# Altium schematic sheet ad7124.SchDoc
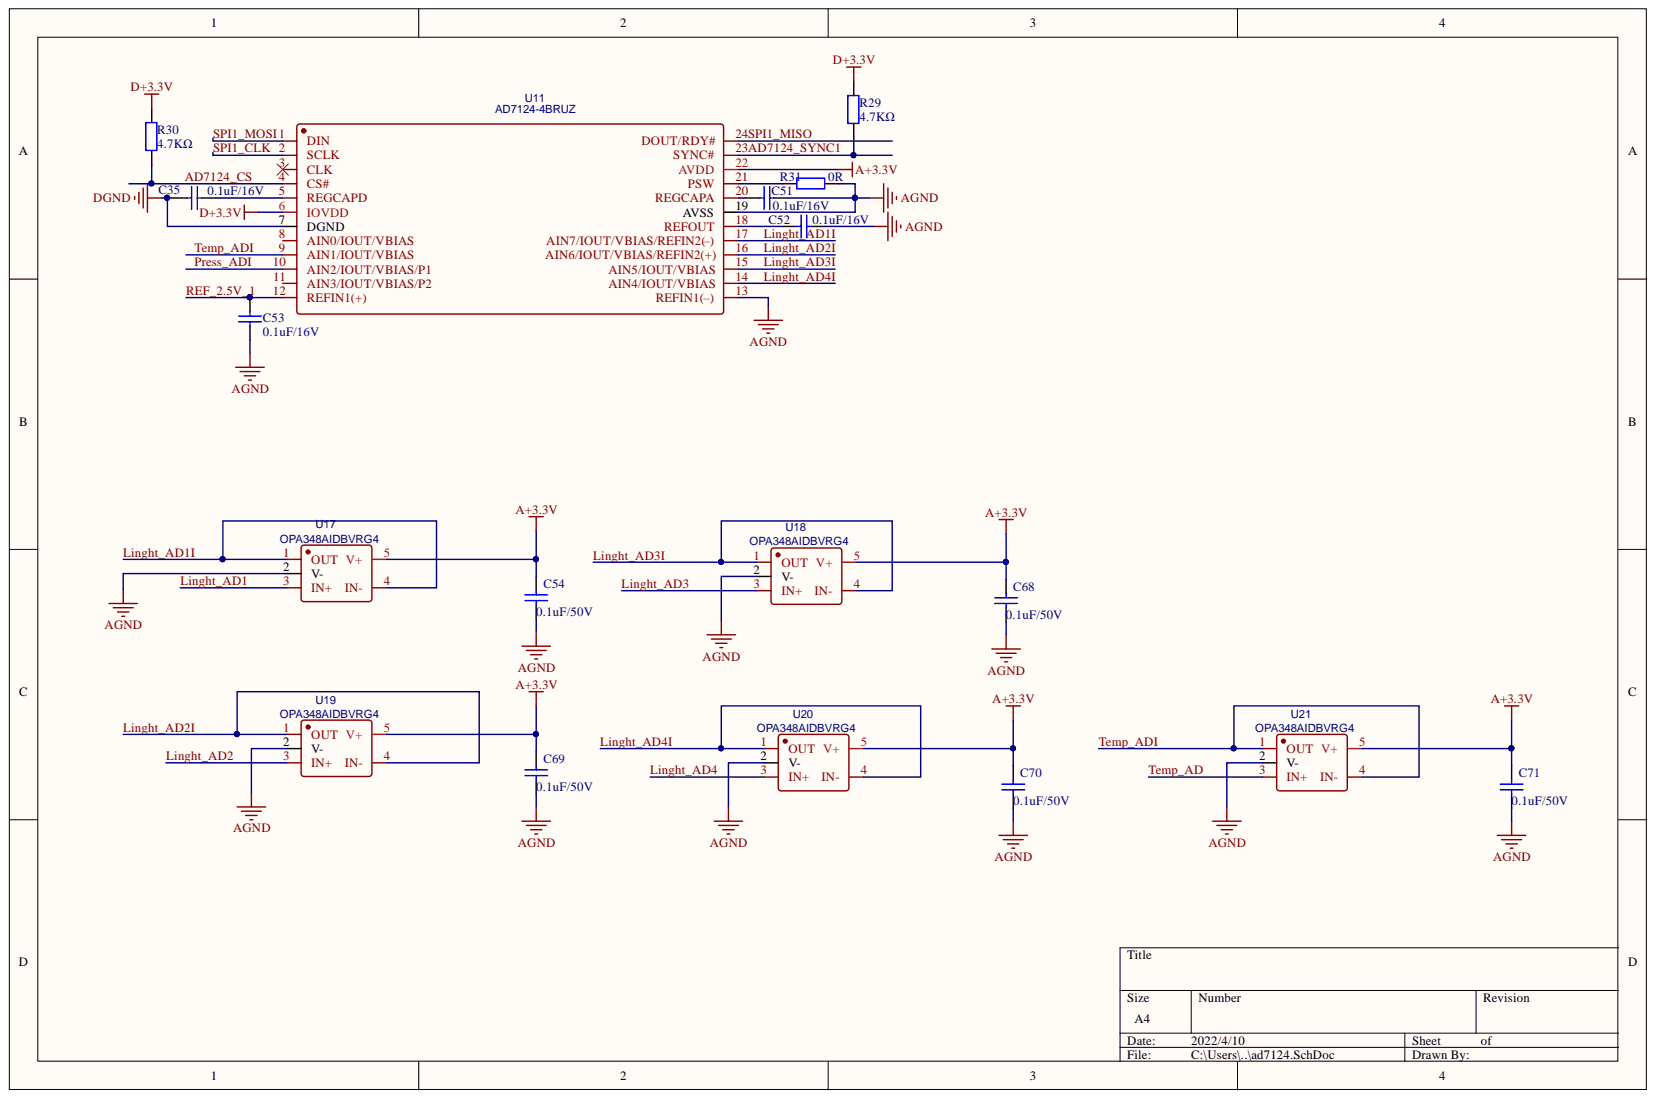
[diagram p0: ad7124.SchDoc]

## Parts
C35  0.1uF/16V  [C0603]
C51  0.1uF/16V  [C0603]
C52  0.1uF/16V  [C0603]
C53  0.1uF/16V  [C0603]
C54  0.1uF/50V  [C0603]
C68  0.1uF/50V  [C0603]
C69  0.1uF/50V  [C0603]
C70  0.1uF/50V  [C0603]
C71  0.1uF/50V  [C0603]
R29  4.7KΩ  [R0603]
R30  4.7KΩ  [R0603]
R31  0R  [C0603]
U11  AD7124-4BRUZ  [TSSOP-24]
U17  OPA348AIDBVRG4  [SOT-23-5]
U18  OPA348AIDBVRG4  [SOT-23-5]
U19  OPA348AIDBVRG4  [SOT-23-5]
U20  OPA348AIDBVRG4  [SOT-23-5]
U21  OPA348AIDBVRG4  [SOT-23-5]

## Nets
A+3.3V: C54.2 C68.2 C69.2 C70.2 C71.2 U11.22(AVDD) U17.5(V+) U18.5(V+) U19.5(V+) U20.5(V+) U21.5(V+)
AGND: C51.1 C52.2 C53.2 C54.1 C68.1 C69.1 C70.1 C71.1 R31.2 U11.13(REFIN1(–)) U11.19(AVSS) U17.2(V-) U18.2(V-) U19.2(V-) U20.2(V-) U21.2(V-)
D+3.3V: R29.2 R30.2 U11.6(IOVDD)
DGND: C35.2 U11.7(DGND)
AD7124_CS: R30.1 U11.4(CS#)
AD7124_SYNC1: R29.1 U11.23(SYNC#)
Linght_AD1: U17.3(IN+)
Linght_AD1I: U11.17(AIN7/IOUT/VBIAS/REFIN2(–)) U17.1(OUT) U17.4(IN-)
Linght_AD2: U19.3(IN+)
Linght_AD2I: U11.16(AIN6/IOUT/VBIAS/REFIN2(+)) U19.1(OUT) U19.4(IN-)
Linght_AD3: U18.3(IN+)
Linght_AD3I: U11.15(AIN5/IOUT/VBIAS) U18.1(OUT) U18.4(IN-)
Linght_AD4: U20.3(IN+)
Linght_AD4I: U11.14(AIN4/IOUT/VBIAS) U20.1(OUT) U20.4(IN-)
Press_ADI: U11.10(AIN2/IOUT/VBIAS/P1)
REF_2.5V_1: C53.1 U11.12(REFIN1(+))
SPI1_CLK: U11.2(SCLK)
SPI1_MISO: U11.24(DOUT/RDY#)
SPI1_MOSI: U11.1(DIN)
Temp_AD: U21.3(IN+)
Temp_ADI: U11.9(AIN1/IOUT/VBIAS) U21.1(OUT) U21.4(IN-)
NetC35_1: C35.1 U11.5(REGCAPD)
NetC51_2: C51.2 U11.20(REGCAPA)
NetC52_1: C52.1 U11.18(REFOUT)
NetR31_1: R31.1 U11.21(PSW)

Not connected: U11 3(CLK) 8(AIN0/IOUT/VBIAS) 11(AIN3/IOUT/VBIAS/P2)

## Library data of the parts
C35 C51-C53 = 0402 10nF (103) 10% 50V: 贴片电容; Component Kind: Standard; ComponentLink1Description: 供应商链接; ComponentLink1URL: http://www.szlcsc.com/product/details_15869.html; Library Name: Cap.SchLib; Package: 0402; Supplier: LC; SuppliersPartNumber: C15195
C54 C68-C71 = Cap: Capacitor; Value: 10uF/10V; Component Kind: Standard; LatestRevisionDate: 17-Jul-2002; LatestRevisionNote: Re-released for DXP Platform.; Library Name: LIB-T.SCHLIB; PackageDescription: Radial Cap, Thru-Hole; 2 Leads; 0.3 in Pin Spacing; PackageReference: RAD-0.3; Published: 8-Jun-2000; Simulation: CAP; Publisher: Altium Limited
R29-R31 = 0603 4.7Ω (4R70) 1%: 贴片电阻; Component Kind: Standard; ComponentLink1Description: 供应商链接; ComponentLink1URL: http://www.szlcsc.com/product/details_23891.html; Library Name: RES.SchLib; Package: 0603; Supplier: LC; SuppliersPartNumber: C23164
U11 = AD7124-4BRUZ: BOM_Manufacturer: ADI; BOM_Manufacturer Part: AD7124-4BRUZ; BOM_Supplier: LCSC; BOM_Supplier Part: C67717; Component Kind: Standard; spicePre: X; spiceSymbolName: AD7124-4BRUZ
U17-U21 = OPA348AIDBVRG4: BOM_Manufacturer: TI; SMT Type: Extend; BOM_JLCPCB SMD: Yes; BOM_Manufacturer Part: OPA348AIDBVRG4; BOM_Supplier: LCSC; BOM_Supplier Part: C46142; Component Kind: Standard; image: //image.lceda.cn/szlcsc/C46142.jpg; spicePre: U; spiceSymbolName: OPA348AIDBVRG4
